# Altium schematic sheet Balancing_Circuit_Plus.SchDoc(Balancing_Circuit_Plus)
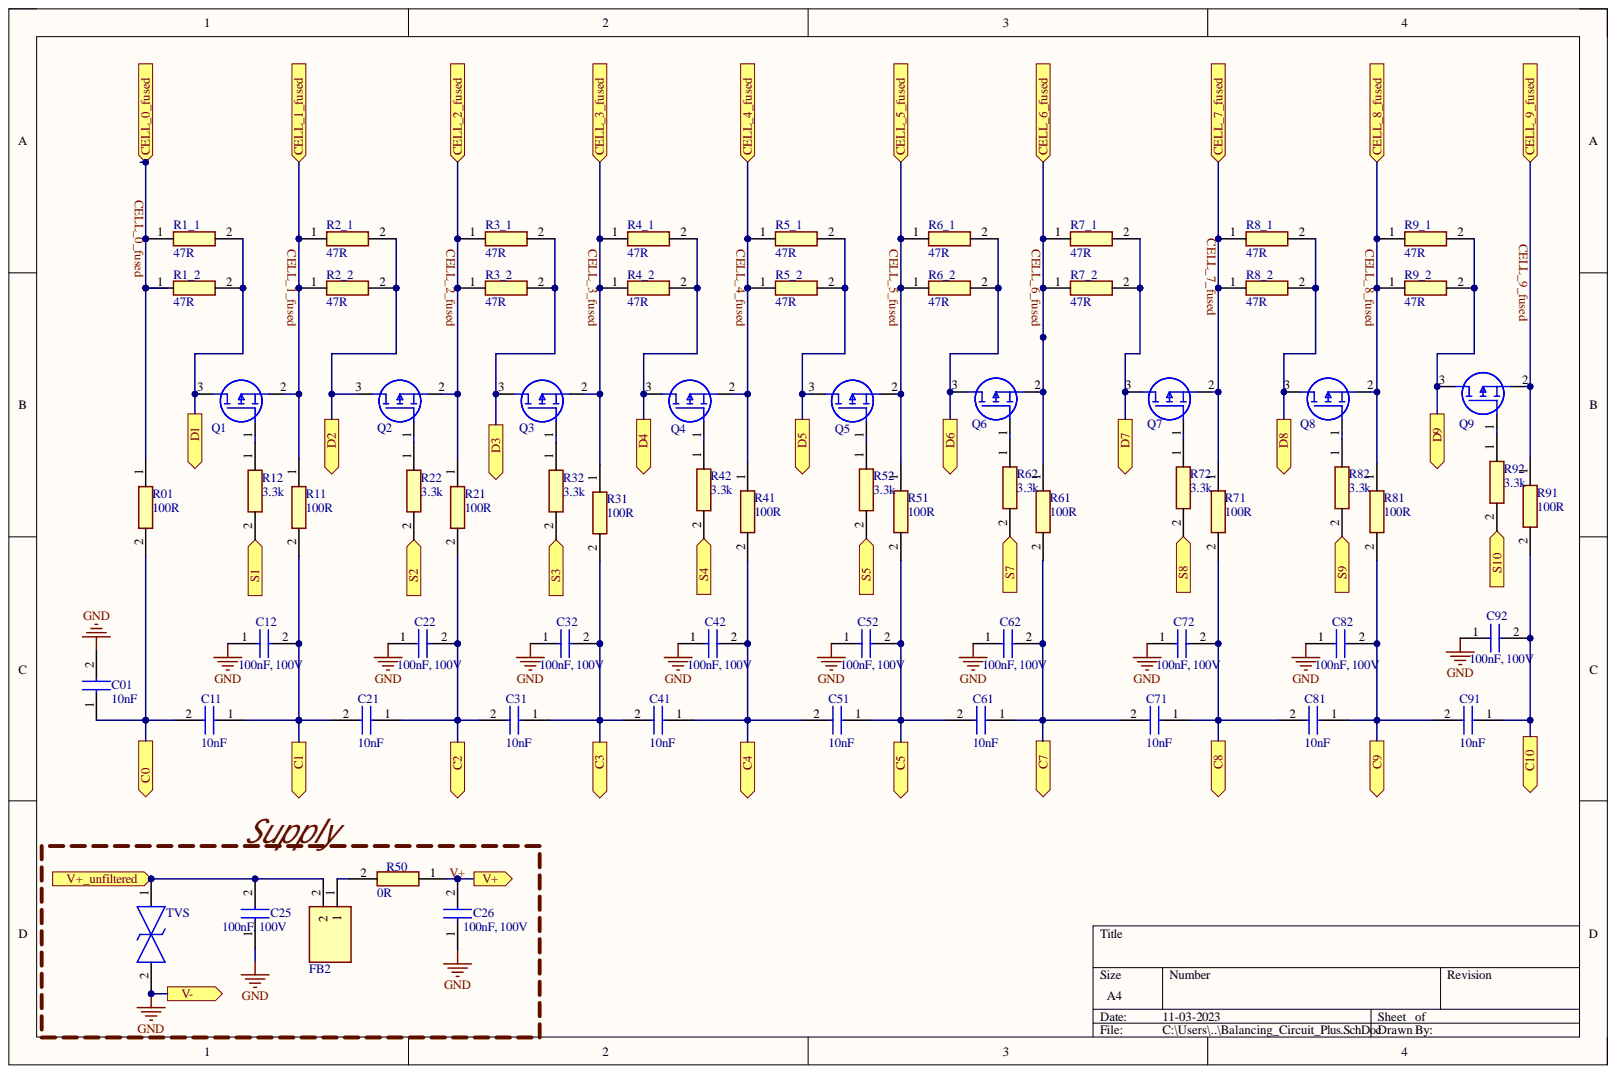
[diagram p0: Balancing_Circuit_Plus.SchDoc]

## Parts
C01  10nF  [CAPC1608X90N]
C11  10nF  [CAPC1608X90N]
C12  100nF, 100V  [CAPC1608X90N]
C21  10nF  [CAPC1608X90N]
C22  100nF, 100V  [CAPC1608X90N]
C25  100nF, 100V  [CAPC1608X90N]
C26  100nF, 100V  [CAPC1608X90N]
C31  10nF  [CAPC1608X90N]
C32  100nF, 100V  [CAPC1608X90N]
C41  10nF  [CAPC1608X90N]
C42  100nF, 100V  [CAPC1608X90N]
C51  10nF  [CAPC1608X90N]
C52  100nF, 100V  [CAPC1608X90N]
C61  10nF  [CAPC1608X90N]
C62  100nF, 100V  [CAPC1608X90N]
C71  10nF  [CAPC1608X90N]
C72  100nF, 100V  [CAPC1608X90N]
C81  10nF  [CAPC1608X90N]
C82  100nF, 100V  [CAPC1608X90N]
C91  10nF  [CAPC1608X90N]
C92  100nF, 100V  [CAPC1608X90N]
FB2  MMZ1608SHE102ATD25  [BEADC1608X95N]  (value not drawn)
Q1  BSS308PE_H6327  [SOT95P260X110-3N]  (value not drawn)
Q2  BSS308PE_H6327  [SOT95P260X110-3N]  (value not drawn)
Q3  BSS308PE_H6327  [SOT95P260X110-3N]  (value not drawn)
Q4  BSS308PE_H6327  [SOT95P260X110-3N]  (value not drawn)
Q5  BSS308PE_H6327  [SOT95P260X110-3N]  (value not drawn)
Q6  BSS308PE_H6327  [SOT95P260X110-3N]  (value not drawn)
Q7  BSS308PE_H6327  [SOT95P260X110-3N]  (value not drawn)
Q8  BSS308PE_H6327  [SOT95P260X110-3N]  (value not drawn)
Q9  BSS308PE_H6327  [SOT95P260X110-3N]  (value not drawn)
R01  100R  [RESC1608X55N]
R1_1  47R  [RESC6432X65N]
R1_2  47R  [RESC6432X65N]
R2_1  47R  [RESC6432X65N]
R2_2  47R  [RESC6432X65N]
R3_1  47R  [RESC6432X65N]
R3_2  47R  [RESC6432X65N]
R4_1  47R  [RESC6432X65N]
R4_2  47R  [RESC6432X65N]
R5_1  47R  [RESC6432X65N]
R5_2  47R  [RESC6432X65N]
R6_1  47R  [RESC6432X65N]
R6_2  47R  [RESC6432X65N]
R7_1  47R  [RESC6432X65N]
R7_2  47R  [RESC6432X65N]
R8_1  47R  [RESC6432X65N]
R8_2  47R  [RESC6432X65N]
R9_1  47R  [RESC6432X65N]
R9_2  47R  [RESC6432X65N]
R11  100R  [RESC1608X55N]
R12  3.3k  [RESC1608X55N]
R21  100R  [RESC1608X55N]
R22  3.3k  [RESC1608X55N]
R31  100R  [RESC1608X55N]
R32  3.3k  [RESC1608X55N]
R41  100R  [RESC1608X55N]
R42  3.3k  [RESC1608X55N]
R50  0R  [RESC1608X55N]
R51  100R  [RESC1608X55N]
R52  3.3k  [RESC1608X55N]
R61  100R  [RESC1608X55N]
R62  3.3k  [RESC1608X55N]
R71  100R  [RESC1608X55N]
R72  3.3k  [RESC1608X55N]
R81  100R  [RESC1608X55N]
R82  3.3k  [RESC1608X55N]
R91  100R  [RESC1608X55N]
R92  3.3k  [RESC1608X55N]
TVS  SMCJ78CA-Q  [DIONM7959X262N]  (value not drawn)

## Ports
C0, C1, C2, C3, C4, C5, C7, C8, C9, C10, CELL_0_fused, CELL_1_fused, CELL_2_fused, CELL_3_fused, CELL_4_fused, CELL_5_fused, CELL_6_fused, CELL_7_fused, CELL_8_fused, CELL_9_fused, D1, D2, D3, D4, D5, D6, D7, D8, D9, S1, S2, S3, S4, S5, S7, S8, S9, S10, V+, V+_unfiltered, V-

## Nets
GND: C01.2 C12.1 C22.1 C25.1 C26.1 C32.1 C42.1 C52.1 C62.1 C72.1 C82.1 C92.1 TVS.2
C0: C01.1 C11.2 R01.2 ; port C0
C1: C11.1 C12.2 C21.2 R11.2 ; port C1
C2: C21.1 C22.2 C31.2 R21.2 ; port C2
C3: C31.1 C32.2 C41.2 R31.2 ; port C3
C4: C41.1 C42.2 C51.2 R41.2 ; port C4
C5: C51.1 C52.2 C61.2 R51.2 ; port C5
C7: C61.1 C62.2 C71.2 R61.2 ; port C7
C8: C71.1 C72.2 C81.2 R71.2 ; port C8
C9: C81.1 C82.2 C91.2 R81.2 ; port C9
C10: C91.1 C92.2 R91.2 ; port C10
CELL_0_fused: R01.1 R1_1.1 R1_2.1 ; port CELL_0_fused
CELL_1_fused: Q1.2 R2_1.1 R2_2.1 R11.1 ; port CELL_1_fused
CELL_2_fused: Q2.2 R3_1.1 R3_2.1 R21.1 ; port CELL_2_fused
CELL_3_fused: Q3.2 R4_1.1 R4_2.1 R31.1 ; port CELL_3_fused
CELL_4_fused: Q4.2 R5_1.1 R5_2.1 R41.1 ; port CELL_4_fused
CELL_5_fused: Q5.2 R6_1.1 R6_2.1 R51.1 ; port CELL_5_fused
CELL_6_fused: Q6.2 R7_1.1 R7_2.1 R61.1 ; port CELL_6_fused
CELL_7_fused: Q7.2 R8_1.1 R8_2.1 R71.1 ; port CELL_7_fused
CELL_8_fused: Q8.2 R9_1.1 R9_2.1 R81.1 ; port CELL_8_fused
CELL_9_fused: Q9.2 R91.1 ; port CELL_9_fused
D1: Q1.3 R1_1.2 R1_2.2 ; port D1
D2: Q2.3 R2_1.2 R2_2.2 ; port D2
D3: Q3.3 R3_1.2 R3_2.2 ; port D3
D4: Q4.3 R4_1.2 R4_2.2 ; port D4
D5: Q5.3 R5_1.2 R5_2.2 ; port D5
D6: Q6.3 R6_1.2 R6_2.2 ; port D6
D7: Q7.3 R7_1.2 R7_2.2 ; port D7
D8: Q8.3 R8_1.2 R8_2.2 ; port D8
D9: Q9.3 R9_1.2 R9_2.2 ; port D9
S1: R12.2 ; port S1
S2: R22.2 ; port S2
S3: R32.2 ; port S3
S4: R42.2 ; port S4
S5: R52.2 ; port S5
S7: R62.2 ; port S7
S8: R72.2 ; port S8
S9: R82.2 ; port S9
S10: R92.2 ; port S10
V+: C26.2 R50.1 ; port V+
V+_unfiltered: C25.2 FB2.2 TVS.1 ; port V+_unfiltered
NetFB2_1: FB2.1 R50.2
NetQ1_1: Q1.1 R12.1
NetQ2_1: Q2.1 R22.1
NetQ3_1: Q3.1 R32.1
NetQ4_1: Q4.1 R42.1
NetQ5_1: Q5.1 R52.1
NetQ6_1: Q6.1 R62.1
NetQ7_1: Q7.1 R72.1
NetQ8_1: Q8.1 R82.1
NetQ9_1: Q9.1 R92.1

## Library data of the parts
C01 C11 C12 C21 C22 C25 C26 C31 C32 C41 C42 C51 C52 C61 C62 C71 C72 C81 C82 C91 C92 = 06035C101K4T2A: Capacitor; Manufacturer_Name: AVX; Component Kind: Standard; Datasheet Link: https://componentsearchengine.com/Datasheets/1/06031A100FAT2A.pdf; Height: 0.9mm; Manufacturer_Part_Number: 06035C101K4T2A; Mouser Part Number: 581-06035C101K4T2A; Mouser Price/Stock: https://www.mouser.co.uk/ProductDetail/AVX/06035C101K4T2A/?qs=%252Bwax1qqoKK9kpvNfpp2NFA%3D%3D
  C01 C11 C12 C21 C22 C31 C32 C41 C42 C51 C52 C61 C62 C71 C72 C81 C82 C91 C92: Library Name: BMS_Slave.SchLib
FB2 = MMZ1608SHE102ATD25: Ferrite Bead; Manufacturer_Name: TDK; Component Kind: Standard; Datasheet Link: https://product.tdk.com/system/files/dam/doc/product/emc/emc/beads/catalog/beads_automotive_signal_mmz1608-he_en.pdf; Height: 0.95mm; Library Name: BMS_schlib.SchLib; Manufacturer_Part_Number: MMZ1608SHE102ATD25; Mouser Part Number: 810-MMZ1608SHE102ATD; Mouser Price/Stock: https://www.mouser.co.uk/ProductDetail/TDK/MMZ1608SHE102ATD25?qs=Jslch3jnSjlR%2F2OQ%252B8tYFg%3D%3D
Q1-Q9 = BSS308PE_H6327: MOSFET (P-Channel); Manufacturer_Name: Infineon; Manufacturer_Part_Number: BSS308PE H6327; Component Kind: Standard; Datasheet Link: https://componentsearchengine.com/Datasheets/1/BSS308PE H6327.pdf; Height: 1.1mm; Library Name: BMS_schlib.SchLib; Mouser Part Number: 726-BSS308PEH6327; Mouser Price/Stock: https://www.mouser.co.uk/ProductDetail/Infineon-Technologies/BSS308PE-H6327?qs=oPPfIaWL1l0trIaeR9VW8A%3D%3D
R01 R11 R12 R21 R22 R31 R32 R41 R42 R50-R52 R61 R62 R71 R72 R81 R82 R91 R92 = CR0603-J_-9R1ELF: 0603 Resistor; Manufacturer_Name: Bourns; Manufacturer_Part_Number: CR0603-J/-9R1ELF; Component Kind: Standard; Datasheet Link: https://www.bourns.com/pdfs/chpreztr.pdf; Height: 0.55mm; Mouser Part Number: 652-CR0603-J/-9R1ELF; Mouser Price/Stock: https://www.mouser.co.uk/ProductDetail/Bourns/CR0603-J-9R1ELF?qs=2as47fUcDMQnJV7FLitZAw%3D%3D
R1_1 R1_2 R2_1 R2_2 R3_1 R3_2 R4_1 R4_2 R5_1 R5_2 R6_1 R6_2 R7_1 R7_2 R8_1 R8_2 R9_1 R9_2 = CRGH2512J47R: Resistor; Manufacturer_Name: TE Connectivity; Component Kind: Standard; Datasheet Link: https://datasheet.datasheetarchive.com/originals/distributors/DKDS-21/403272.pdf; Height: 0.65mm; Library Name: BMS_Slave.SchLib; Manufacturer_Part_Number: CRGH2512J47R; Mouser Part Number: 279-CRGH2512J47R; Mouser Price/Stock: https://www.mouser.co.uk/ProductDetail/TE-Connectivity-Holsworthy/CRGH2512J47R?qs=iJVBkmKXgrmiH24AZKXpXg%3D%3D
TVS = SMCJ78CA-Q: TVS Diode (Bi-directional); Manufacturer_Name: Bourns; Component Kind: Standard; Datasheet Link: https://bourns.com/docs/product-datasheets/smcj-q.pdf; Height: 2.62mm; Library Name: BMS_schlib.SchLib; Manufacturer_Part_Number: SMCJ78CA-Q; Mouser Part Number: 652-SMCJ78CA-Q; Mouser Price/Stock: https://www.mouser.co.uk/ProductDetail/Bourns/SMCJ78CA-Q?qs=zW32dvEIR3v8tW0NuzyQMA%3D%3D
